# Altium schematic sheet Main.SchDoc
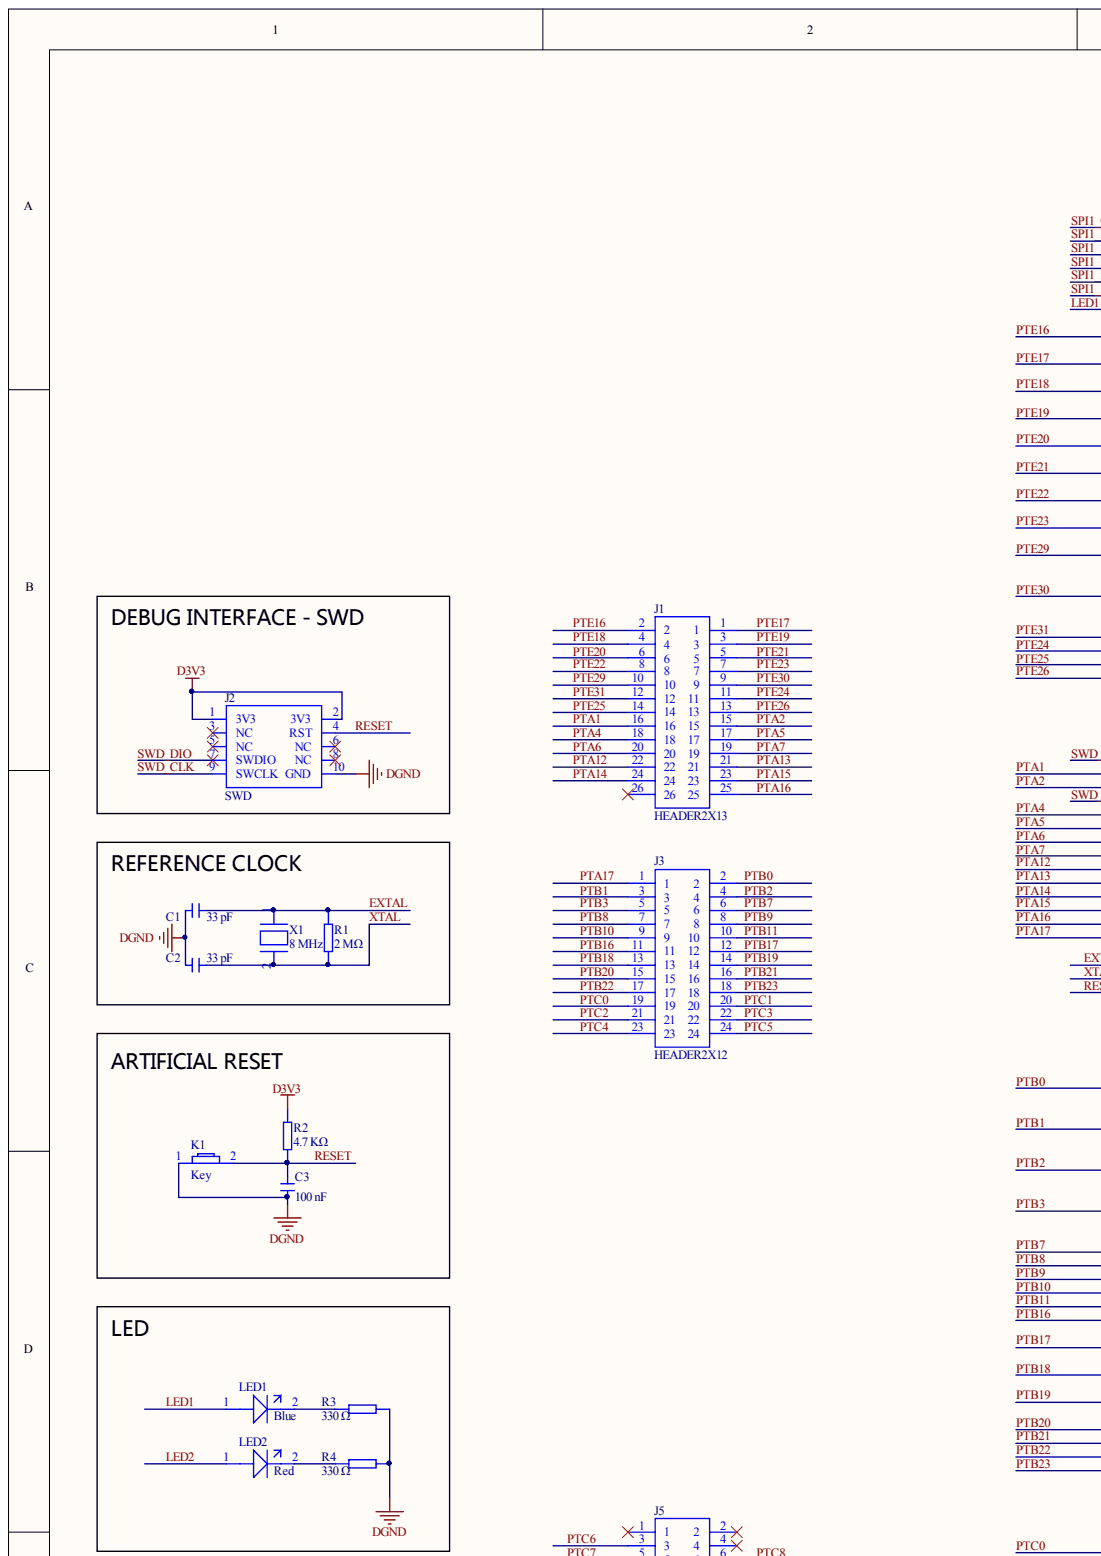
[diagram p0: Main.SchDoc, top left]
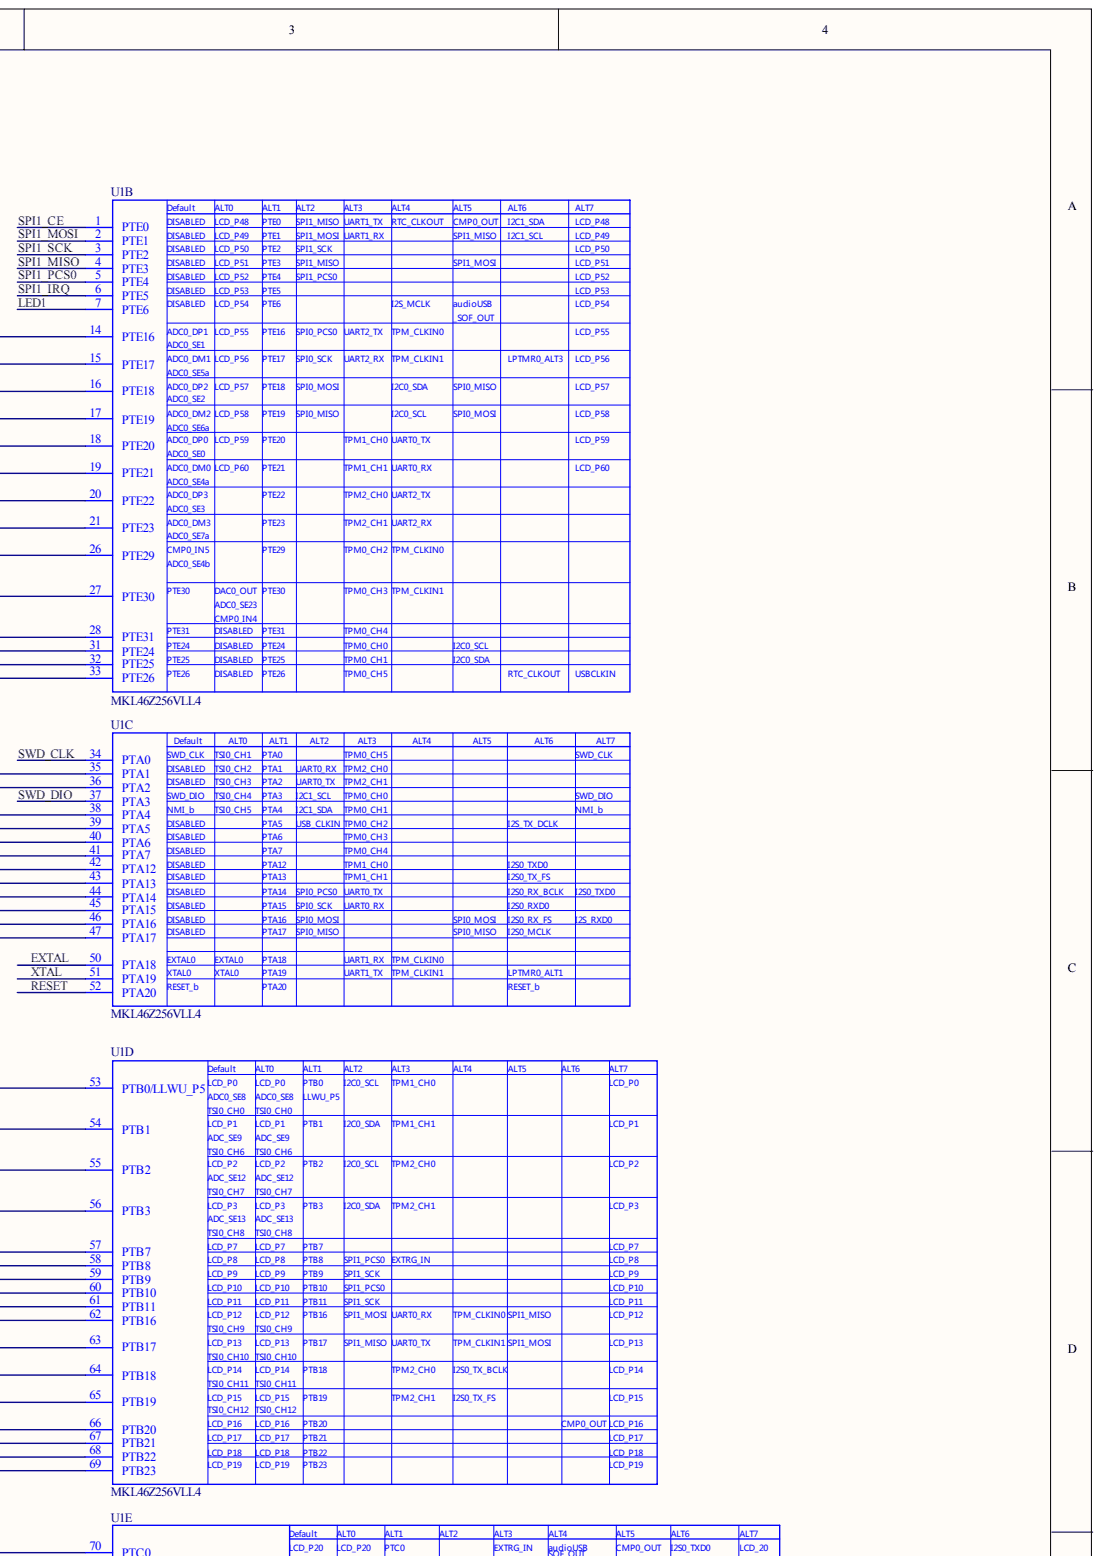
[diagram p1: Main.SchDoc, top right]
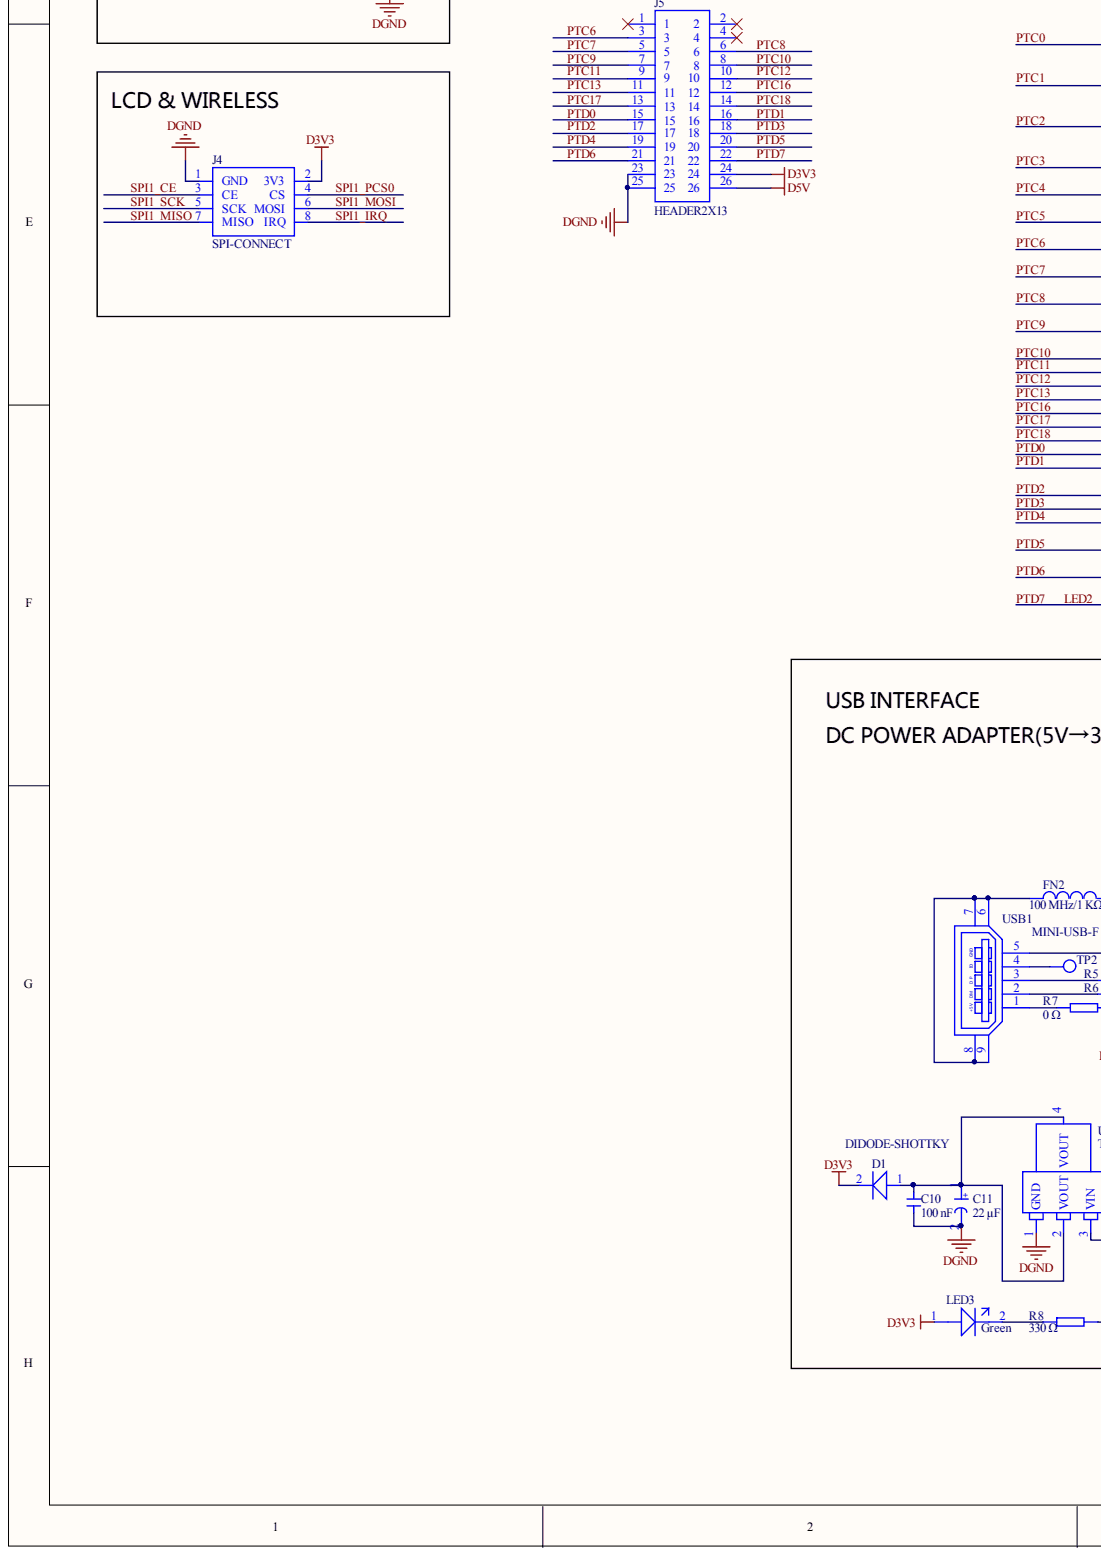
[diagram p2: Main.SchDoc, bottom left]
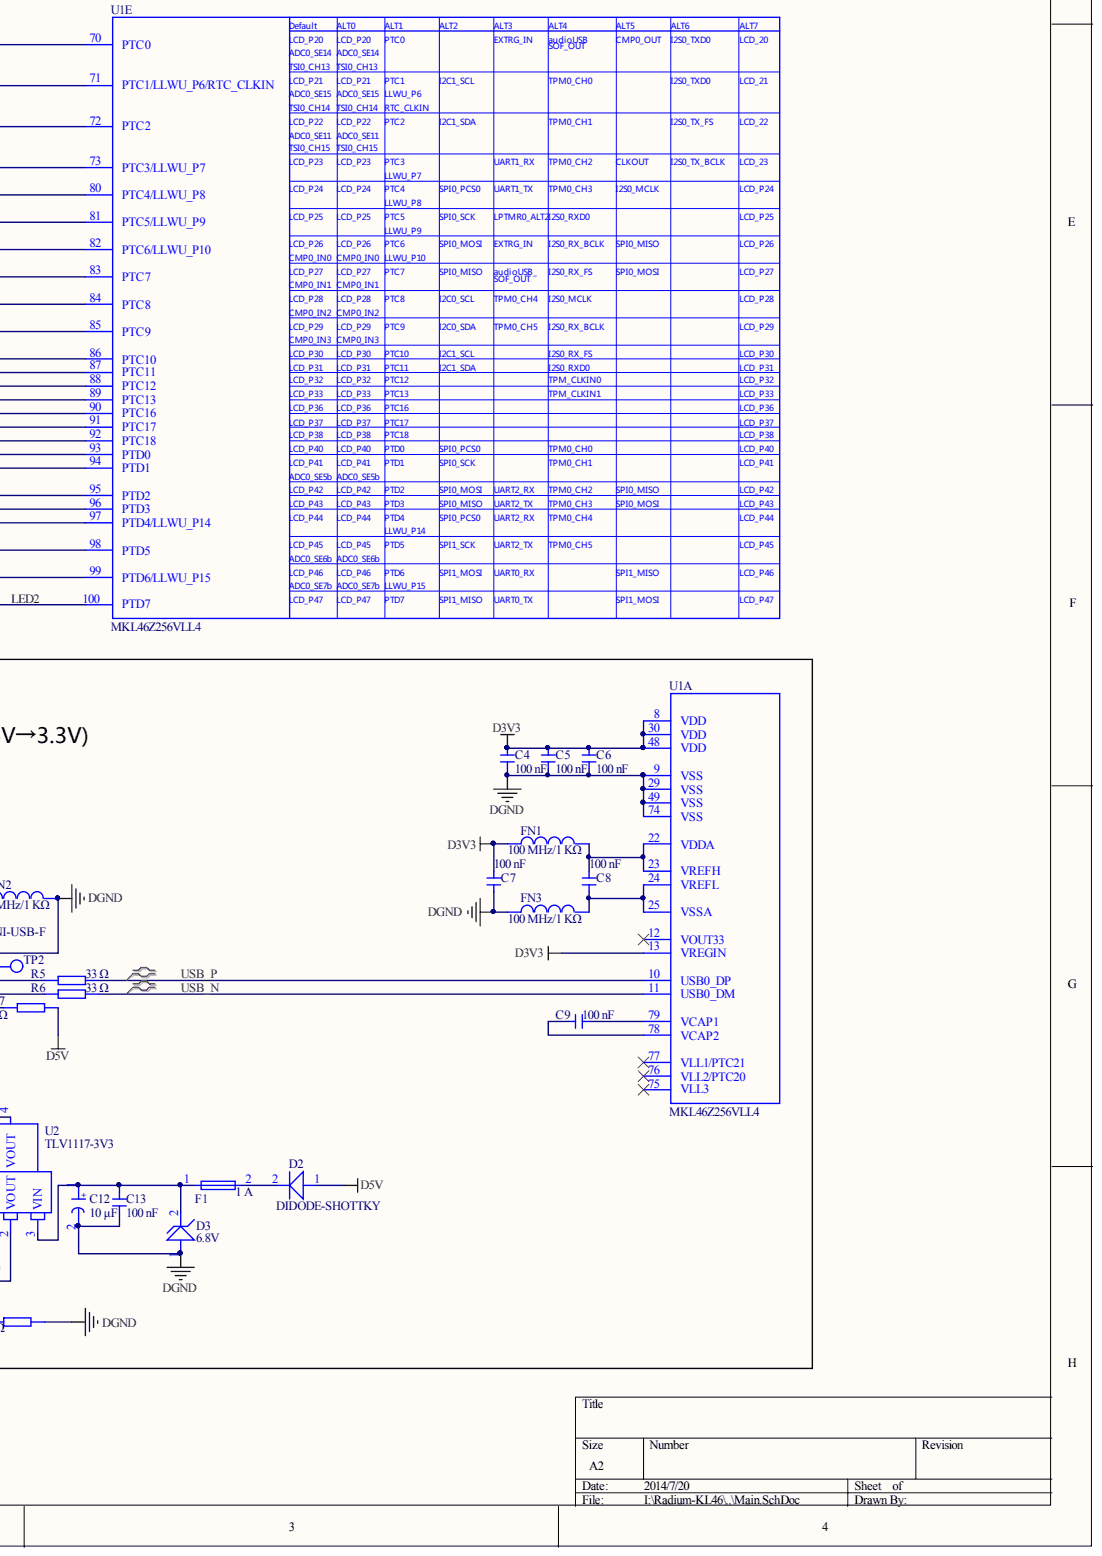
[diagram p3: Main.SchDoc, bottom right]

## Parts
C1  33 pF
C2  33 pF
C3  100 nF
C4  100 nF
C5  100 nF
C6  100 nF
C7  100 nF
C8  100 nF
C9  100 nF
C10  100 nF
C11  22 μF
C12  10 μF
C13  100 nF
D1  DIDODE-SHOTTKY
D2  DIDODE-SHOTTKY
D3  6.8V
F1  1 A
FN1  100 MHz/1 KΩ
FN2  100 MHz/1 KΩ
FN3  100 MHz/1 KΩ
J1  HEADER2X13
J2  SWD
J3  HEADER2X12
J4  SPI-CONNECT
J5  HEADER2X13
K1  Key
LED1  Blue
LED2  Red
LED3  Green
R1  2 MΩ
R2  4.7 KΩ
R3  330 Ω
R4  330 Ω
R5  33 Ω
R6  33 Ω
R7  0 Ω
R8  330 Ω
TP2  TestPoint  (value not drawn)
U1  MKL46Z256VLL4  (parts A,B,C,D,E)
U2  TLV1117-3V3
USB1  MINI-USB-F
X1  8 MHz

## Nets
D3V3: C4.2 C5.2 C6.2 C7.2 D1.2 FN1.1 J2.1(3V3) J2.2(3V3) J4.2(3V3) J5.24 LED3.1 R2.2 U1.8 U1.13(VREGIN) U1.30(VDD) U1.48(VDD)
D5V: D2.1 J5.26 R7.2
DGND: C1.2 C2.2 C3.2 C4.1 C5.1 C6.1 C7.1 C10.1 C11.2 C12.2 C13.1 D3.1 FN2.2 FN3.1 J2.10(GND) J4.1(GND) J5.23 J5.25 K1.1 R3.2 R4.2 R8.2 U1.9(VSS) U1.29(VSS) U1.49(VSS) U1.74(VSS) U2.1(GND) USB1.5
EXTAL: C1.1 R1.2 U1.50(PTA18) X1.1
LED1: LED1.1 U1.7(PTE6)
LED2: J5.22 LED2.1 U1.100(PTD7)
PTA1: J1.16 U1.35(PTA1)
PTA2: J1.15 U1.36(PTA2)
PTA4: J1.18 U1.38(PTA4)
PTA5: J1.17 U1.39(PTA5)
PTA6: J1.20 U1.40(PTA6)
PTA7: J1.19 U1.41(PTA7)
PTA12: J1.22 U1.42(PTA12)
PTA13: J1.21 U1.43(PTA13)
PTA14: J1.24 U1.44(PTA14)
PTA15: J1.23 U1.45(PTA15)
PTA16: J1.25 U1.46(PTA16)
PTA17: J3.1 U1.47(PTA17)
PTB0: J3.2 U1.53(PTB0/LLWU_P5)
PTB1: J3.3 U1.54(PTB1)
PTB2: J3.4 U1.55(PTB2)
PTB3: J3.5 U1.56(PTB3)
PTB7: J3.6 U1.57(PTB7)
PTB8: J3.7 U1.58(PTB8)
PTB9: J3.8 U1.59(PTB9)
PTB10: J3.9 U1.60(PTB10)
PTB11: J3.10 U1.61(PTB11)
PTB16: J3.11 U1.62(PTB16)
PTB17: J3.12 U1.63(PTB17)
PTB18: J3.13 U1.64(PTB18)
PTB19: J3.14 U1.65(PTB19)
PTB20: J3.15 U1.66(PTB20)
PTB21: J3.16 U1.67(PTB21)
PTB22: J3.17 U1.68(PTB22)
PTB23: J3.18 U1.69(PTB23)
PTC0: J3.19 U1.70(PTC0)
PTC1: J3.20 U1.71(PTC1/LLWU_P6/RTC_CLKIN)
PTC2: J3.21 U1.72(PTC2)
PTC3: J3.22 U1.73(PTC3/LLWU_P7)
PTC4: J3.23 U1.80(PTC4/LLWU_P8)
PTC5: J3.24 U1.81(PTC5/LLWU_P9)
PTC6: J5.3 U1.82(PTC6/LLWU_P10)
PTC7: J5.5 U1.83(PTC7)
PTC8: J5.6 U1.84(PTC8)
PTC9: J5.7 U1.85(PTC9)
PTC10: J5.8 U1.86(PTC10)
PTC11: J5.9 U1.87(PTC11)
PTC12: J5.10 U1.88(PTC12)
PTC13: J5.11 U1.89(PTC13)
PTC16: J5.12 U1.90(PTC16)
PTC17: J5.13 U1.91(PTC17)
PTC18: J5.14 U1.92(PTC18)
PTD0: J5.15 U1.93(PTD0)
PTD1: J5.16 U1.94(PTD1)
PTD2: J5.17 U1.95(PTD2)
PTD3: J5.18 U1.96(PTD3)
PTD4: J5.19 U1.97(PTD4/LLWU_P14)
PTD5: J5.20 U1.98(PTD5)
PTD6: J5.21 U1.99(PTD6/LLWU_P15)
PTE16: J1.2 U1.14(PTE16)
PTE17: J1.1 U1.15(PTE17)
PTE18: J1.4 U1.16(PTE18)
PTE19: J1.3 U1.17(PTE19)
PTE20: J1.6 U1.18(PTE20)
PTE21: J1.5 U1.19(PTE21)
PTE22: J1.8 U1.20(PTE22)
PTE23: J1.7 U1.21(PTE23)
PTE24: J1.11 U1.31(PTE24)
PTE25: J1.14 U1.32(PTE25)
PTE26: J1.13 U1.33(PTE26)
PTE29: J1.10 U1.26(PTE29)
PTE30: J1.9 U1.27(PTE30)
PTE31: J1.12 U1.28(PTE31)
RESET: C3.1 J2.4(RST) K1.2 R2.1 U1.52(PTA20)
SPI1_CE: J4.3(CE) U1.1(PTE0)
SPI1_IRQ: J4.8(IRQ) U1.6(PTE5)
SPI1_MISO: J4.7(MISO) U1.4(PTE3)
SPI1_MOSI: J4.6(MOSI) U1.2(PTE1)
SPI1_PCS0: J4.4(CS) U1.5(PTE4)
SPI1_SCK: J4.5(SCK) U1.3(PTE2)
SWD_CLK: J2.9(SWCLK) U1.34(PTA0)
SWD_DIO: J2.7(SWDIO) U1.37(PTA3)
USB_N: R6.2 U1.11(USB0_DM)
USB_P: R5.2 U1.10(USB0_DP)
XTAL: C2.1 R1.1 U1.51(PTA19) X1.2
NetC8_1: C8.1 FN3.2 U1.24(VREFL) U1.25
NetC8_2: C8.2 FN1.2 U1.22(VDDA) U1.23(VREFH)
NetC9_1: C9.1 U1.79(VCAP1)
NetC9_2: C9.2 U1.78(VCAP2)
NetC10_2: C10.2 C11.1 D1.1 U2.2(VOUT) U2.4(VOUT)
NetC12_1: C12.1 C13.2 D3.2 F1.1 U2.3(VIN)
NetD2_2: D2.2 F1.2
NetFN2_1: FN2.1 USB1.6 USB1.7 USB1.8 USB1.9
NetLED1_2: LED1.2 R3.1
NetLED2_2: LED2.2 R4.1
NetLED3_2: LED3.2 R8.1
NetR5_1: R5.1 USB1.3
NetR6_1: R6.1 USB1.2
NetR7_1: R7.1 USB1.1
NetTP2_1: TP2.1 USB1.4

Not connected: J1 26; J2 3(NC) 5(NC) 6(NC) 8(NC); J5 1 2 4; U1 12(VOUT33) 75 76(VLL2/PTC20) 77(VLL1/PTC21)

## Library data of the parts
C1 C2 = (no symbol name): C104: 100 nF; C103: 10 nF; C102: 1 nF; C101: 100 pF; C100: 10 pF; C105: 1 μF; C106: 10 μF; C226: 22 μF; C330: 33 pF
C3-C10 C13 = (no symbol name): C103: 10 nF; C102: 1 nF; C101: 100 pF; C100: 10 pF; C105: 1 μF; C106: 10 μF; C226: 22 μF; C330: 33 pF; C104: 100 nF
C11 = (no symbol name): C106: 10 μF; C226: 22 μF
C12 = (no symbol name): C226: 22 μF; C106: 10 μF
D1 D2 = (no symbol name): IN5819: SS14
F1 = (no symbol name): F500mA: 500 mA; F1A: 1 A
R1 = (no symbol name): R101: 100 Ω; R331: 330 Ω; R102: 1 KΩ; R472: 4.7 KΩ; R103: 10 KΩ; R0: 0 Ω; R471: 470 Ω; R330: 33 Ω; R106: 2 MΩ
R2 = (no symbol name): R101: 100 Ω; R331: 330 Ω; R102: 1 KΩ; R103: 10 KΩ; R0: 0 Ω; R471: 470 Ω; R106: 2 MΩ; R330: 33 Ω; R472: 4.7 KΩ
R3 R4 R8 = (no symbol name): R101: 100 Ω; R102: 1 KΩ; R472: 4.7 KΩ; R103: 10 KΩ; R0: 0 Ω; R471: 470 Ω; R106: 2 MΩ; R330: 33 Ω; R331: 330 Ω
R5 R6 = (no symbol name): R101: 100 Ω; R331: 330 Ω; R102: 1 KΩ; R472: 4.7 KΩ; R103: 10 KΩ; R0: 0 Ω; R471: 470 Ω; R106: 2 MΩ; R330: 33 Ω
R7 = (no symbol name): R101: 100 Ω; R331: 330 Ω; R102: 1 KΩ; R472: 4.7 KΩ; R103: 10 KΩ; R471: 470 Ω; R106: 2 MΩ; R330: 33 Ω; R0: 0 Ω
X1 = (no symbol name): X16: 16 MHz; X8: 8 MHz
D3 FN1-FN3 J1-J5 K1 LED1-LED3 TP2 U1 U2 USB1 = (no symbol name)
  D3: T6V8: 6.8V
  FN1-FN3: Fe102: 100 MHz/1 KΩ
  K1: Key: Key
  LED1-LED3: Blue: Blue; Red: Red; White: White; Green: Green
